# Altium schematic sheet SuperCap-NEW_V1.4.SchDoc(SuperCap-NEW_V1.4)
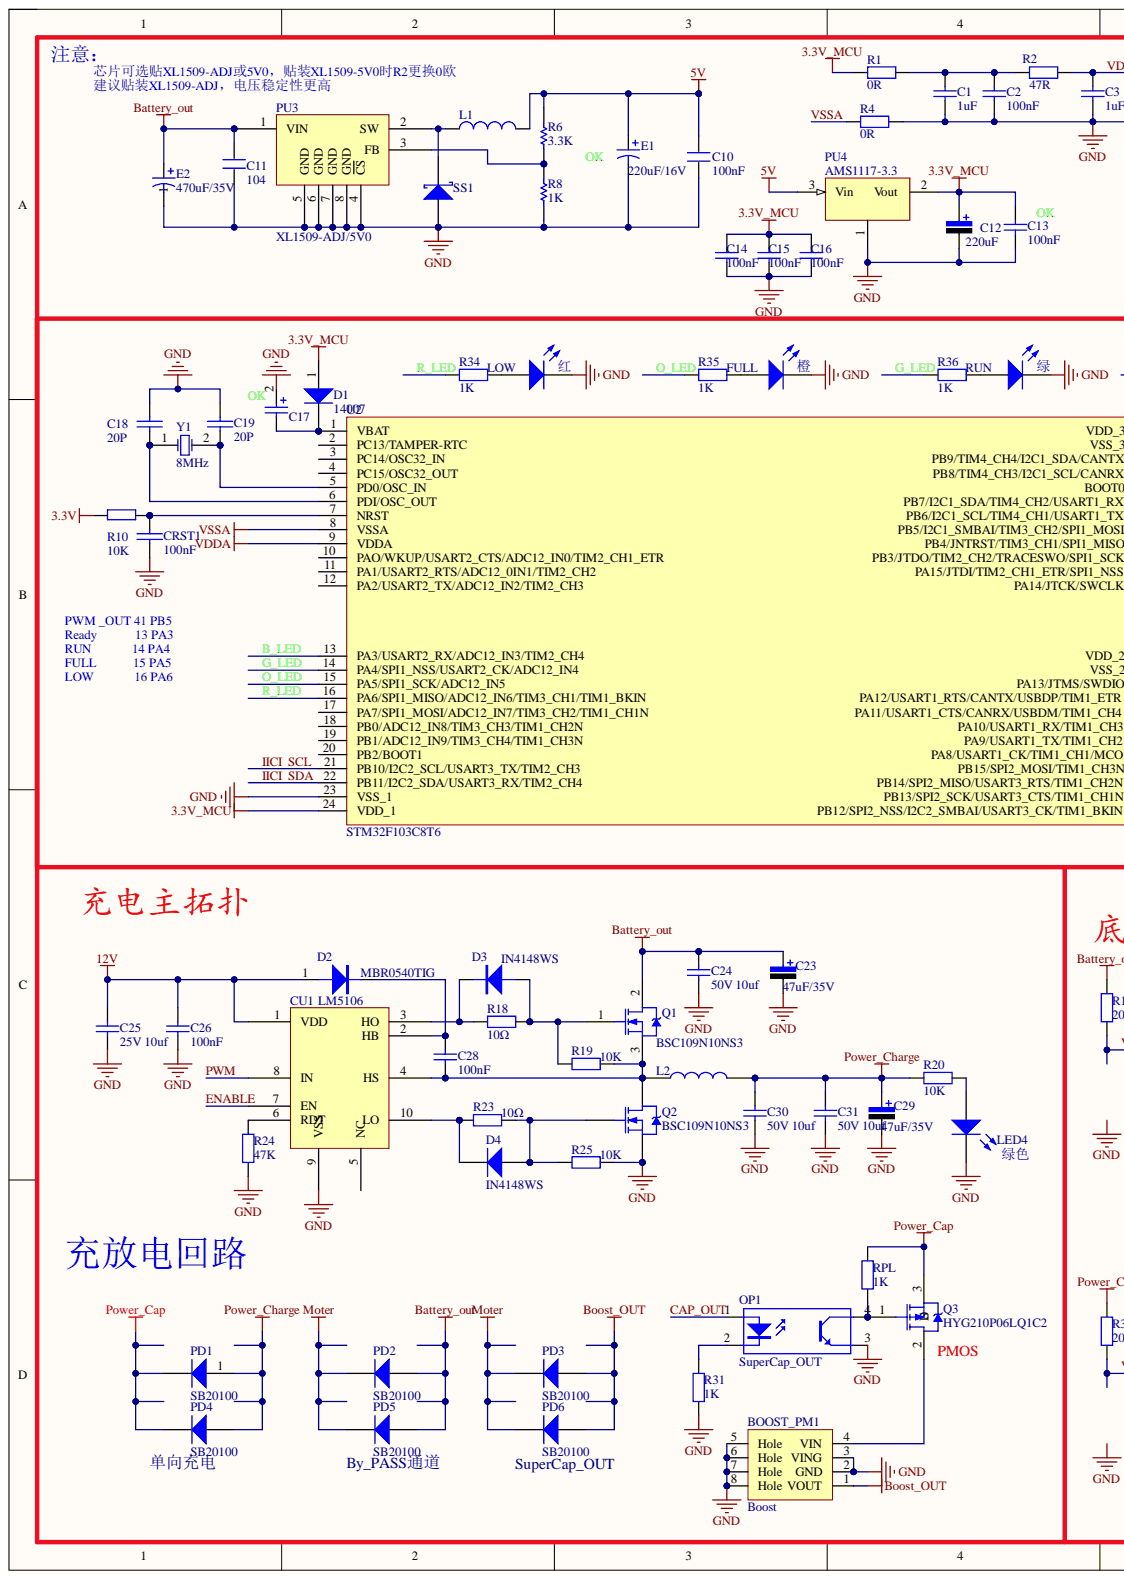
[diagram p0: SuperCap-NEW_V1.4.SchDoc, left]
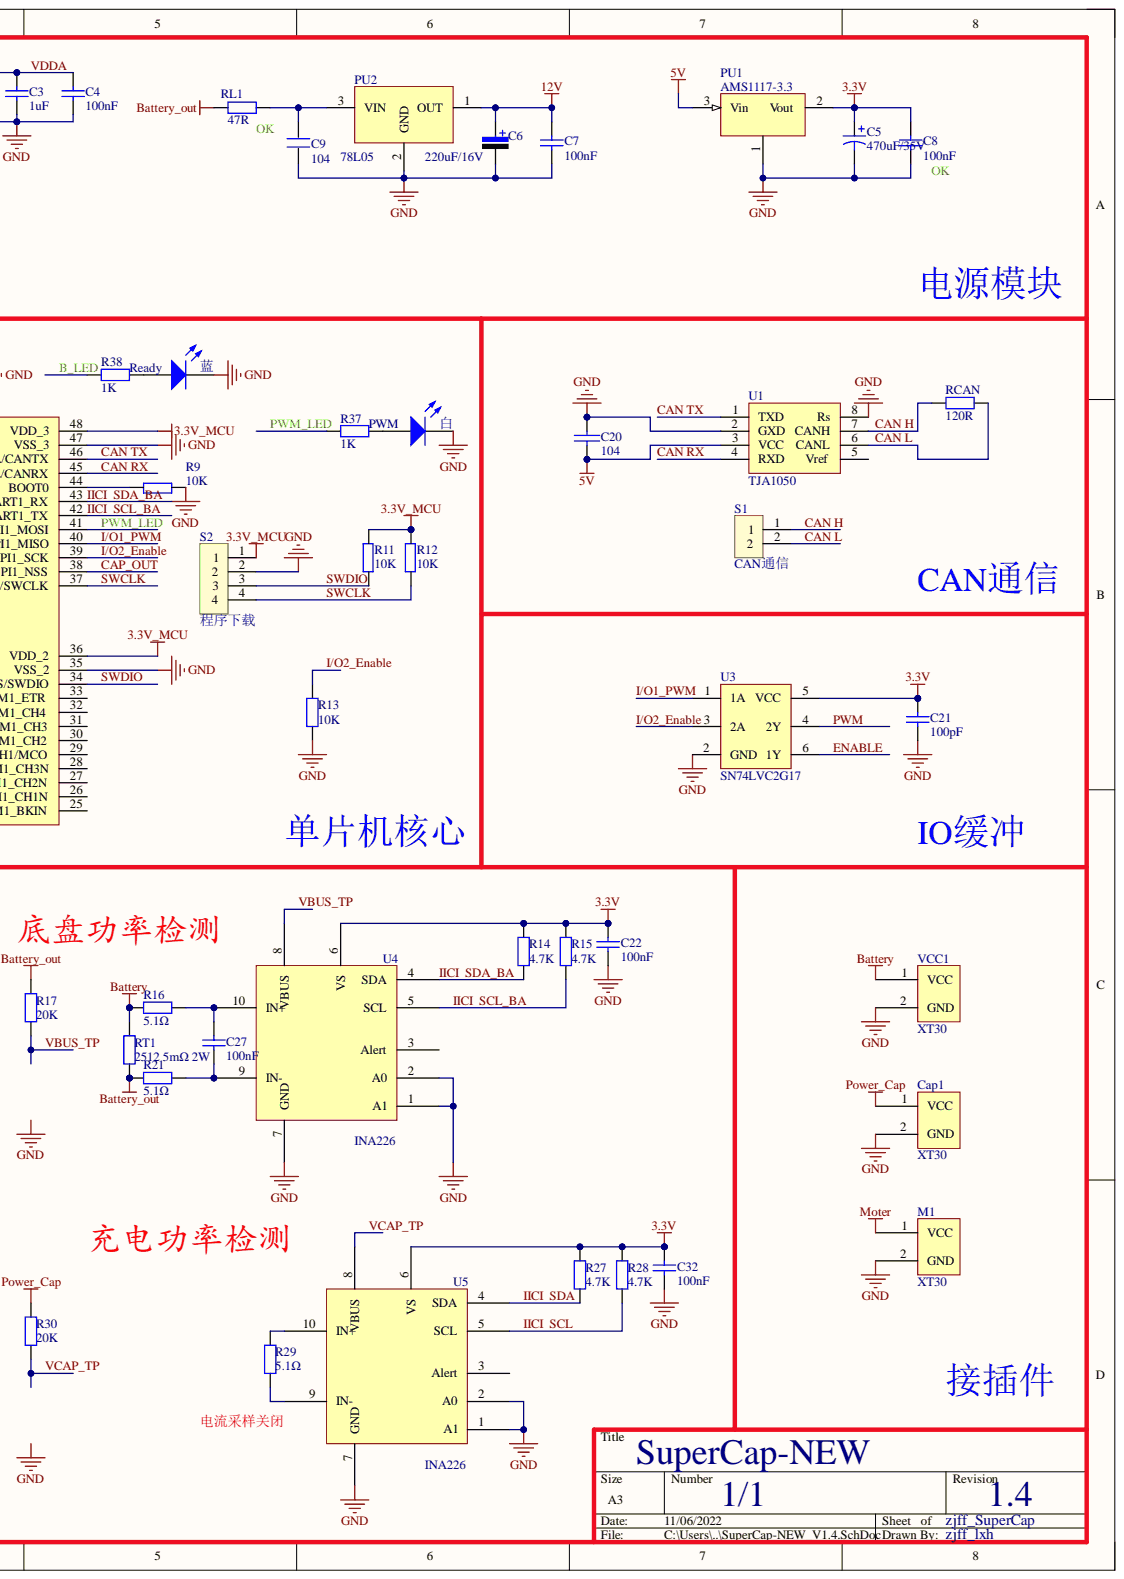
[diagram p1: SuperCap-NEW_V1.4.SchDoc, right]

## Parts
BOOST_PM1  Boost  [Boost_Block]
C1  1uF  [0603C]
C2  100nF  [0603C]
C3  1uF  [0603C]
C4  100nF  [0603C]
C5  value 470uF/35V  [CAP-SMD_BD6.3-L6.6-W6.6-FD]  (hidden comment: Cap Pol1)
C6  220uF/16V  [CAP-SMD_BD6.3-L6.6-W6.6-FD]
C7  100nF  [0603C]
C8  100nF  [0603C]
C9  104  [0603C]
C10  100nF  [0603C]
C11  104  [0603C]
C12  220uF  [CAP-SMD_BD6.3-L6.6-W6.6-FD]
C13  100nF  [0603C]
C14  100nF  [0603C]
C15  100nF  [0603C]
C16  100nF  [0603C]
C17  470uF/10V  [CAP-SMD_BD6.3-L6.6-W6.6-FD]  (value not drawn)
C18  20P  [0603C]
C19  20P  [0603C]
C20  104  [0603C]
C21  value 100pF  [0603C]  (hidden comment: Cap)
C22  100nF  [0603C]
C23  47uF/35V  [CAP-SMD_BD6.3-L6.6-W6.6-FD]
C24  50V 10uf  [0603C]
C25  25V 10uf  [0603C]
C26  100nF  [0603C]
C27  100nF  [0603C]
C28  100nF  [0603C]
C29  47uF/35V  [CAP-SMD_BD6.3-L6.6-W6.6-FD]
C30  50V 10uf  [0603C]
C31  50V 10uf  [0603C]
C32  100nF  [0603C]
Cap1  XT30  [XT30]
CRST1  100nF  [0603C]
CU1  LM5106  [MSOP_10]
D1  14007  [SOD123]
D2  MBR0540TIG  [SOD-123_L2.8-W1.8-LS3.7-FD]
D3  IN4148WS  [SOD123]
D4  IN4148WS  [SOD123]
E1  value 220uF/16V  [CAP-SMD_BD8.0-L8.3-W8.3-FD]  (hidden comment: Cap Pol1)
E2  value 470uF/35V  [470uF 35V SMT]  (hidden comment: Cap Pol1)
FULL  橙  [LED_0805]
L1  Inductor  [L 6*6]  (value not drawn)
L2  68UH  [IND-TH_L14.0-W6.5-P8.00-D0.6]  (value not drawn)
LED4  绿色  [LED_0805]
LOW  红  [LED_0805]
M1  XT30  [XT30]
OP1  SuperCap_OUT  [SOP-4_L6.5-W4.6-P2.54-LS10.2-TL]
PD1  SB20100  [TO-277_3P-L5.4-W4.0-LS6.5-TR]
PD2  SB20100  [TO-277_3P-L5.4-W4.0-LS6.5-TR]
PD3  SB20100  [TO-277_3P-L5.4-W4.0-LS6.5-TR]
PD4  SB20100  [TO-277_3P-L5.4-W4.0-LS6.5-TR]
PD5  SB20100  [TO-277_3P-L5.4-W4.0-LS6.5-TR]
PD6  SB20100  [TO-277_3P-L5.4-W4.0-LS6.5-TR]
PU1  AMS1117-3.3  [SOT-223]
PU2  78L05  [SOT-89-3_L4.5-W2.5-P1.50-LS4.2-BR]
PU3  XL1509-ADJ/5V0  [SOP8 N]
PU4  AMS1117-3.3  [SOT-223]
PWM  白  [LED_0805]
Q1  BSC109N10NS3  [PDFN-8_L4.9-W5.8-P1.27-LS6.1-BL_2]
Q2  BSC109N10NS3  [PDFN-8_L4.9-W5.8-P1.27-LS6.1-BL_2]
Q3  HYG210P06LQ1C2  [MOS_PQFN]
R1  0R  [R0603]
R2  47R  [R0603]
R4  0R  [R0603]
R6  value 3.3K  [R0603]  (hidden comment: Res1)
R8  value 1K  [R0603]  (hidden comment: Res1)
R9  10K  [0603-1]
R10  10K  [0603-1]
R11  10K  [0603-1]
R12  10K  [0603-1]
R13  value 10K  [0603-1]  (hidden comment: Res2)
R14  4.7K  [0603-1]
R15  4.7K  [0603-1]
R16  5.1Ω  [0603-1]
R17  value 20K  [0603-1]  (hidden comment: Res2)
R18  10Ω  [0603-1]
R19  10K  [0603-1]
R20  10K  [R0603]
R21  5.1Ω  [0603-1]
R23  10Ω  [0603-1]
R24  47K  [0603-1]
R25  10K  [0603-1]
R27  4.7K  [0603-1]
R28  4.7K  [0603-1]
R29  5.1Ω  [0603-1]
R30  value 20K  [0603-1]  (hidden comment: Res2)
R31  1K  [0603-1]
R34  value 1K  [0603-1]  (hidden comment: Res2)
R35  value 1K  [0603-1]  (hidden comment: Res2)
R36  value 1K  [0603-1]  (hidden comment: Res2)
R37  value 1K  [0603-1]  (hidden comment: Res2)
R38  value 1K  [0603-1]  (hidden comment: Res2)
RCAN  value 120R  [0603-1]  (hidden comment: Res2)
Ready  蓝  [LED_0805]
RL1  47R  [0603-1]
RPL  1K  [0603-1]
RT1  2512 5mΩ 2W  [FUSE-SMD_L6.1-W2.7 - duplicate]
RUN  绿  [LED_0805]
S1  CAN通信  [1.25_2Pin]
S2  程序下载  [S-4]
SS1  D Schottky  [贴片M7]  (value not drawn)
U1  TJA1050  [SOP8 N]
U2  STM32F103C8T6  [LQFP-48_M]
U3  SN74LVC2G17  [SOT-363_L2.0-W1.3-P0.65-LS2.1-BR]
U4  INA226  [MSOP_10]
U5  INA226  [MSOP_10]
VCC1  XT30  [XT30]
Y1  8MHz  [X-SM49]

## Nets
3.3V: C5.1 C8.1 C21.2 C22.1 C32.1 PU1.2 R10.2 R14.1 R15.1 R27.1 R28.1 U3.5(VCC) U4.6(VS) U5.6(VS)
3.3V_MCU: C12.1 C13.1 C14.1 C15.1 C16.1 D1.1 PU4.2 R1.1 R11.1 R12.1 S2.1 U2.24(VDD_1) U2.36(VDD_2) U2.48(VDD_3)
5V: C10.2 C20.2 E1.1 L1.2 PU1.3 PU4.3 R6.2 U1.3(VCC)
12V: C6.1 C7.1 C25.1 C26.1 CU1.1(VDD) D2.1 PU2.1
Battery: R16.2 RT1.1 VCC1.1
Battery_out: C11.2 C23.1 C24.1 E2.1 PD2.1 PD5.1 PU3.1(VIN) Q1.2 R17.1 R21.2 RL1.1 RT1.2
Boost_OUT: BOOST_PM1.1(VOUT) PD3.1 PD6.1
GND: BOOST_PM1.2(GND) BOOST_PM1.3(VING) BOOST_PM1.5(Hole) BOOST_PM1.6(Hole) BOOST_PM1.7(Hole) BOOST_PM1.8(Hole) C1.1 C2.2 C3.2 C4.2 C5.2 C6.2 C7.2 C8.2 C9.1 C10.1 C11.1 C12.2 C13.2 C14.2 C15.2 C16.2 C17.2 C18.1 C19.1 C20.1 C21.1 C22.2 C23.2 C24.2 C25.2 C26.2 C29.2 C30.2 C31.2 C32.2 Cap1.2 CRST1.2 CU1.9(VSS) E1.2 E2.2 FULL.2 LED4.2 LOW.2 M1.2 OP1.3 PU1.1 PU2.2 PU3.4(CS) PU3.5(GND) PU3.6(GND) PU3.7(GND) PU3.8(GND) PU4.1 PWM.2 Q2.3 R4.2 R8.1 R9.2 R13.2 R24.2 R25.2 R31.2 Ready.2 RUN.2 S2.2 SS1.1 U1.2(GXD) U1.8(Rs) U2.23(VSS_1) U2.35(VSS_2) U2.47(VSS_3) U3.2(GND) U4.1(A1) U4.2(A0) U4.7(GND) U5.1(A1) U5.2(A0) U5.7(GND) VCC1.2
Moter: M1.1 PD2.2 PD3.2 PD5.2 PD6.2
Power_Cap: Cap1.1 PD1.2 PD4.2 Q3.3 R30.1 RPL.1
Power_Charge: C29.1 C30.1 C31.1 L2.2 PD1.1 PD4.1 R20.2
B_LED: R38.2 U2.13(PA3/USART2_RX/ADC12_IN3/TIM2_CH4)
CAN H: RCAN.1 S1.1 U1.7(CANH)
CAN L: RCAN.2 S1.2 U1.6(CANL)
CAN RX: U1.4(RXD) U2.45(PB8/TIM4_CH3/I2C1_SCL/CANRX)
CAN TX: U1.1(TXD) U2.46(PB9/TIM4_CH4/I2C1_SDA/CANTX)
CAP_OUT: OP1.1 U2.38(PA15/JTDI/TIM2_CH1_ETR/SPI1_NSS)
ENABLE: CU1.7(EN) U3.6(1Y)
G_LED: R36.2 U2.14(PA4/SPI1_NSS/USART2_CK/ADC12_IN4)
I/O1_PWM: U2.40(PB4/JNTRST/TIM3_CH1/SPI1_MISO) U3.1(1A)
I/O2_Enable: R13.1 U2.39(PB3/JTDO/TIM2_CH2/TRACESWO/SPI1_SCK) U3.3(2A)
IICI  SCL: R28.2 U2.21(PB10/I2C2_SCL/USART3_TX/TIM2_CH3) U5.5(SCL)
IICI  SCL_BA: R15.2 U2.42(PB6/I2C1_SCL/TIM4_CH1/USART1_TX) U4.5(SCL)
IICI  SDA: R27.2 U2.22(PB11/I2C2_SDA/USART3_RX/TIM2_CH4) U5.4(SDA)
IICI  SDA_BA: R14.2 U2.43(PB7/I2C1_SDA/TIM4_CH2/USART1_RX) U4.4(SDA)
O_LED: R35.2 U2.15(PA5/SPI1_SCK/ADC12_IN5)
PWM: CU1.8(IN) U3.4(2Y)
PWM_LED: R37.2 U2.41(PB5/I2C1_SMBAI/TIM3_CH2/SPI1_MOSI)
R_LED: R34.1 U2.16(PA6/SPI1_MISO/ADC12_IN6/TIM3_CH1/TIM1_BKIN)
SWCLK: R12.2 S2.4 U2.37(PA14/JTCK/SWCLK)
SWDIO: R11.2 S2.3 U2.34(PA13/JTMS/SWDIO)
VBUS_TP: R17.2 U4.8(VBUS)
VCAP_TP: R30.2 U5.8(VBUS)
VDDA: C3.1 C4.1 R2.2 U2.9(VDDA)
VSSA: R4.1 U2.8(VSSA)
NetBOOST_PM1_4: BOOST_PM1.4(VIN) Q3.2
NetC1_2: C1.2 C2.1 R1.2 R2.1
NetC9_2: C9.2 PU2.3 RL1.2
NetC17_1: C17.1 D1.2 U2.1(VBAT)
NetC18_2: C18.2 U2.6(PDI/OSC_OUT) Y1.1
NetC19_2: C19.2 U2.5(PD0/OSC_IN) Y1.2
NetC27_1: C27.1 R16.1 U4.10(IN+)
NetC27_2: C27.2 R21.1 U4.9(IN-)
NetC28_1: C28.1 CU1.2(HB) D2.2
NetC28_2: C28.2 CU1.4(HS) L2.1 Q1.3 Q2.2 R19.2
NetCRST1_1: CRST1.1 R10.1 U2.7(NRST)
NetCU1_3: CU1.3(HO) D3.2 R18.1
NetCU1_6: CU1.6(RDT) R24.1
NetCU1_10: CU1.10(LO) D4.2 R23.1
NetD3_1: D3.1 Q1.1 R18.2 R19.1
NetD4_1: D4.1 Q2.1 R23.2 R25.1
NetFULL_1: FULL.1 R35.1
NetL1_1: L1.1 PU3.2(SW) SS1.2
NetLED4_1: LED4.1 R20.1
NetLOW_1: LOW.1 R34.2
NetOP1_2: OP1.2 R31.1
NetOP1_4: OP1.4 Q3.1 RPL.2
NetPU3_3: PU3.3(FB) R6.1 R8.2
NetPWM_1: PWM.1 R37.1
NetR9_1: R9.1 U2.44(BOOT0)
NetR29_1: R29.1 U5.10(IN+)
NetR29_2: R29.2 U5.9(IN-)
NetR36_1: R36.1 RUN.1
NetR38_1: R38.1 Ready.1

Not connected: CU1 5(NC); U1 5(Vref); U2 2(PC13/TAMPER-RTC) 3(PC14/OSC32_IN) 4(PC15/OSC32_OUT) 10(PAO/WKUP/USART2_CTS/ADC12_IN0/TIM2_CH1_ETR) 11(PA1/USART2_RTS/ADC12_0IN1/TIM2_CH2) 12(PA2/USART2_TX/ADC12_IN2/TIM2_CH3) 17(PA7/SPI1_MOSI/ADC12_IN7/TIM3_CH2/TIM1_CH1N) 18(PB0/ADC12_IN8/TIM3_CH3/TIM1_CH2N) 19(PB1/ADC12_IN9/TIM3_CH4/TIM1_CH3N) 20(PB2/BOOT1) 25(PB12/SPI2_NSS/I2C2_SMBAI/USART3_CK/TIM1_BKIN) 26(PB13/SPI2_SCK/USART3_CTS/TIM1_CH1N) 27(PB14/SPI2_MISO/USART3_RTS/TIM1_CH2N) 28(PB15/SPI2_MOSI/TIM1_CH3N) 29(PA8/USART1_CK/TIM1_CH1/MCO) 30(PA9/USART1_TX/TIM1_CH2) 31(PA10/USART1_RX/TIM1_CH3) 32(PA11/USART1_CTS/CANRX/USBDM/TIM1_CH4) 33(PA12/USART1_RTS/CANTX/USBDP/TIM1_ETR); U4 3(Alert); U5 3(Alert)

## Library data of the parts
C1 C3 C24 C25 C30 C31 = Cap: 无极性电容; Value: 100nF; Component Kind: Standard; LatestRevisionDate: 17-Jul-2002; LatestRevisionNote: Re-released for DXP Platform.; PackageReference: RAD-0.3; Published: 8-Jun-2000; Library Name: Fszhang.IntLib; Publisher: Altium Limited
C2 C4 C7 C8 C13-C16 C22 C26-C28 C32 = Cap: 无极性电容; Component Kind: Standard; LatestRevisionDate: 17-Jul-2002; LatestRevisionNote: Re-released for DXP Platform.; PackageReference: RAD-0.3; Published: 8-Jun-2000; Value: 100nF; Library Name: Fszhang.IntLib; Publisher: Altium Limited
C5 E1 E2 = Cap Pol1: Polarized Capacitor (Radial); Component Kind: Standard; LatestRevisionDate: 17-Jul-2002; LatestRevisionNote: Re-released for DXP Platform.; Library Name: Miscellaneous Devices.IntLib; PackageDescription: Polarized Capacitor (Radial); 2 Leads; PackageReference: RB7.6-15; Published: 8-Jun-2000; Publisher: Altium Limited
  C5: Signal Integrity: Cap Pol; Simulation: CAP
C6 C12 C23 C29 = Cap Pol: 极性电解电容; Value: 100uF; Vol: 16V; Component Kind: Standard; LatestRevisionDate: 17-Jul-2002; LatestRevisionNote: Re-released for DXP Platform.; PackageReference: RB7.6-15; Published: 8-Jun-2000; Library Name: Fszhang.IntLib; Publisher: Altium Limited
C9-C11 = C: Component Kind: Standard
  C9: Library Name: 103驱动2.SCHLIB
  C10 C11: Library Name: 南江主控 原理图库.SCHLIB
C17 = Cap Pol1: Polarized Capacitor (Radial); Value: 10uF; Component Kind: Standard; Published: 8-Jun-2000; Revision: July-2002: Re-released for DXP Platform.; Publisher: Altium Limited
C18-C20 CRST1 = CAP-1: Component Kind: Standard; Library Name: ZBC124Schlib1.SchLib
C21 = Cap: Capacitor; Component Kind: Standard; LatestRevisionDate: 17-Jul-2002; LatestRevisionNote: Re-released for DXP Platform.; Library Name: Miscellaneous Devices.IntLib; PackageDescription: Radial Cap, Thru-Hole; 2 Leads; 0.3 in Pin Spacing; PackageReference: RAD-0.3; Published: 8-Jun-2000; Signal Integrity: Cap; Simulation: CAP; Publisher: Altium Limited
Cap1 M1 VCC1 = JP-2: Component Kind: Standard; Library Name: 电源模块.SCHLIB
CU1 U4 U5 = Component_1: Component Kind: Standard
  CU1: Library Name: Schlib3.SchLib
  U4 U5: Library Name: Schlib4.SchLib
D1 D4 PD1-PD6 = Diode 1N914: High Conductance Fast Diode; Code_JEDEC: DO-204-AH; Component Kind: Standard; ComponentLink1Description: Manufacturer Link; ComponentLink1URL: http://www.fairchildsemi.com/; ComponentLink2Description: Datasheet; ComponentLink2URL: http://www.fairchildsemi.com/ds/1N%2F1N4448.pdf; DatasheetVersion: 1997; LatestRevisionDate: 17-Jul-2002; LatestRevisionNote: Re-released for DXP Platform.; Library Name: Miscellaneous Devices.IntLib; PackageDescription: Diode, Axial; Body 3.8 x 1.9 mm (LxDia. max), Lead Dia. 0.53 mm (max); PackageReference: DO-35; Published: 8-Jun-2000; Signal Integrity: 1N914; Simulation: 1N914; Publisher: Altium Limited
D2 D3 = D-1: Component Kind: Standard; Library Name: ZBC124Schlib1.SchLib
FULL LED4 LOW PWM Ready RUN = LED0: 发光二极管; Component Kind: Standard; LatestRevisionDate: 08-Jul-2005; LatestRevisionNote: Mech Layer 1 removed.; PackageReference: LED-0; Published: 8-Jun-2000; Library Name: Fszhang.IntLib; Publisher: Altium Limited
L1 = Inductor: Code_IEC: 1005; Value: 10mH; Code_JEITA: 0402; Component Kind: Standard; Description: Inductor; LatestRevisionDate: 29-May-2009; LatestRevisionNote: IPC-7351 Footprint Added.; Library Name: Miscellaneous Devices.IntLib; PackageDescription: Chip Inductor; PackageReference: 0402-A; Published: 8-Jun-2000; Publisher: Altium Limited
L2 = Inductance: Component Kind: Standard; Library Name: 103驱动2.SCHLIB
OP1 = Optoisolator1: 4-Pin Phototransistor Optocoupler; Component Kind: Standard; DatasheetVersion: Nov-2003; LatestRevisionDate: 04-Mar-2005; LatestRevisionNote: Sch pins 3 & 4 Swapped.; Library Name: Miscellaneous Devices.IntLib; PackageReference: DIP-4; Published: 24-Mar-1999; Publisher: Altium Limited
PU1 PU4 = LD1117: Component Kind: Standard
PU3 = XL1509-ADJ: Component Kind: Standard; Library Name: 电源转接板.SchLib
Q1 Q2 = NMOS-2: N-Channel Power MOSFET; Note: Pin 2 is electrically connected to pin 4.; Component Kind: Standard; ComponentLink1Description: Manufacturer Link; ComponentLink1URL: http://www.irf.com/; DatasheetVersion: PD-9.814; LatestRevisionDate: 17-Jul-2002; LatestRevisionNote: Re-released for DXP Platform.; Library Name: Miscellaneous Devices.IntLib; PackageDescription: TO, Thru-Hole, Vertical, Heatsink Mounted; 3 In-Line Leads; Pitch 2.54 mm; PackageReference: TO-220AB; PackageVersion: Feb-1997; Published: 8-Jun-2000; Simulation: IRF1010; Publisher: Altium Limited
Q3 = PMOS-2: P-Channel Power MOSFET; Note: Pin 4 not drawn and electrically connected to Pin 2.; Component Kind: Standard; ComponentLink1Description: Manufacturer Link; ComponentLink1URL: http://www.irf.com/; DatasheetVersion: PD-9.390D; LatestRevisionDate: 17-Jul-2002; LatestRevisionNote: Re-released for DXP Platform.; Library Name: Miscellaneous Devices.IntLib; PackageDescription: TO, Thru-Hole, Vertical, Heatsink Mounted; 3 In-Line Leads; Pitch 2.54 mm; PackageReference: TO-220AB; PackageVersion: Feb-1997; Published: 8-Jun-2000; Simulation: IRF9510; Publisher: Altium Limited
R1 R2 R4 R14-R16 R18-R21 R23-R25 R27-R29 RL1 RT1 = Res1: 贴片电阻; Value: 1K; Component Kind: Standard; LatestRevisionDate: 17-Jul-2002; LatestRevisionNote: Re-released for DXP Platform.; PackageReference: AXIAL-0.4; Published: 8-Jun-2000; Library Name: Fszhang.IntLib; Publisher: Altium Limited
R6 R8 = Res1: Resistor; Component Kind: Standard; LatestRevisionDate: 17-Jul-2002; LatestRevisionNote: Re-released for DXP Platform.; Library Name: Miscellaneous Devices.IntLib; PackageDescription: Axial Device, Thru-Hole; 2 Leads; 0.3 in Pin Spacing; PackageReference: AXIAL-0.3; Published: 8-Jun-2000; Publisher: Altium Limited
  R6: Signal Integrity: Res; Simulation: RESISTOR
R9-R12 = R: Component Kind: Standard; Library Name: WARSHIP.SCHLIB
R13 R17 R30 R34-R38 RCAN = Res2: Resistor; Component Kind: Standard; LatestRevisionDate: 17-Jul-2002; LatestRevisionNote: Re-released for DXP Platform.; Library Name: Miscellaneous Devices.IntLib; PackageDescription: Axial Device, Thru-Hole; 2 Leads; 0.4 in Pin Spacing; PackageReference: AXIAL-0.4; Published: 8-Jun-2000; Publisher: Altium Limited
  R13 R17 R30 R34-R38: Signal Integrity: Res; Simulation: RESISTOR
R31 RPL = Res1: 贴片电阻; Component Kind: Standard; LatestRevisionDate: 17-Jul-2002; LatestRevisionNote: Re-released for DXP Platform.; PackageReference: AXIAL-0.4; Published: 8-Jun-2000; Value: 1K; Library Name: Fszhang.IntLib; Publisher: Altium Limited
S1 = S-2: Component Kind: Standard; Library Name: ZBC123Schlib1.SchLib
S2 = S-4: Component Kind: Standard; Library Name: ZBC123Schlib1.SchLib
SS1 = D Schottky: Schottky Diode; Code_JEDEC: DO-214-AA; Component Kind: Standard; LatestRevisionDate: 17-Jul-2002; LatestRevisionNote: Re-released for DXP Platform.; Library Name: Miscellaneous Devices.IntLib; PackageDescription: DO-214-AA/SMB; 2 C-Bend Leads; Body 5.3 x 3.6 mm, inc. leads (LxW); PackageReference: SMB; Published: 8-Jun-2000; Publisher: Altium Limited
U1 = 24C02: Component Kind: Standard; Library Name: 底盘主控.SCHLIB
Y1 = XTAL: Crystal Oscillator; Component Kind: Standard; LatestRevisionDate: 17-Jul-2002; LatestRevisionNote: Re-released for DXP Platform.; Library Name: WARSHIP.SCHLIB; Published: 8-Jun-2000; Simulation: XTAL; Publisher: Altium Limited
BOOST_PM1 = Boost: Component Kind: Standard; Library Name: My package.SchLib
PU2 = 78L05: Component Kind: Standard; Library Name: My package.SchLib
U2 = STM32F103C8T6: Component Kind: Standard; Library Name: WARSHIP.SCHLIB
U3 = SN74LVC2G17: Component Kind: Standard; Library Name: My package.SchLib
